# Altium schematic sheet bolid-power-dcdc-ldo.SchDoc
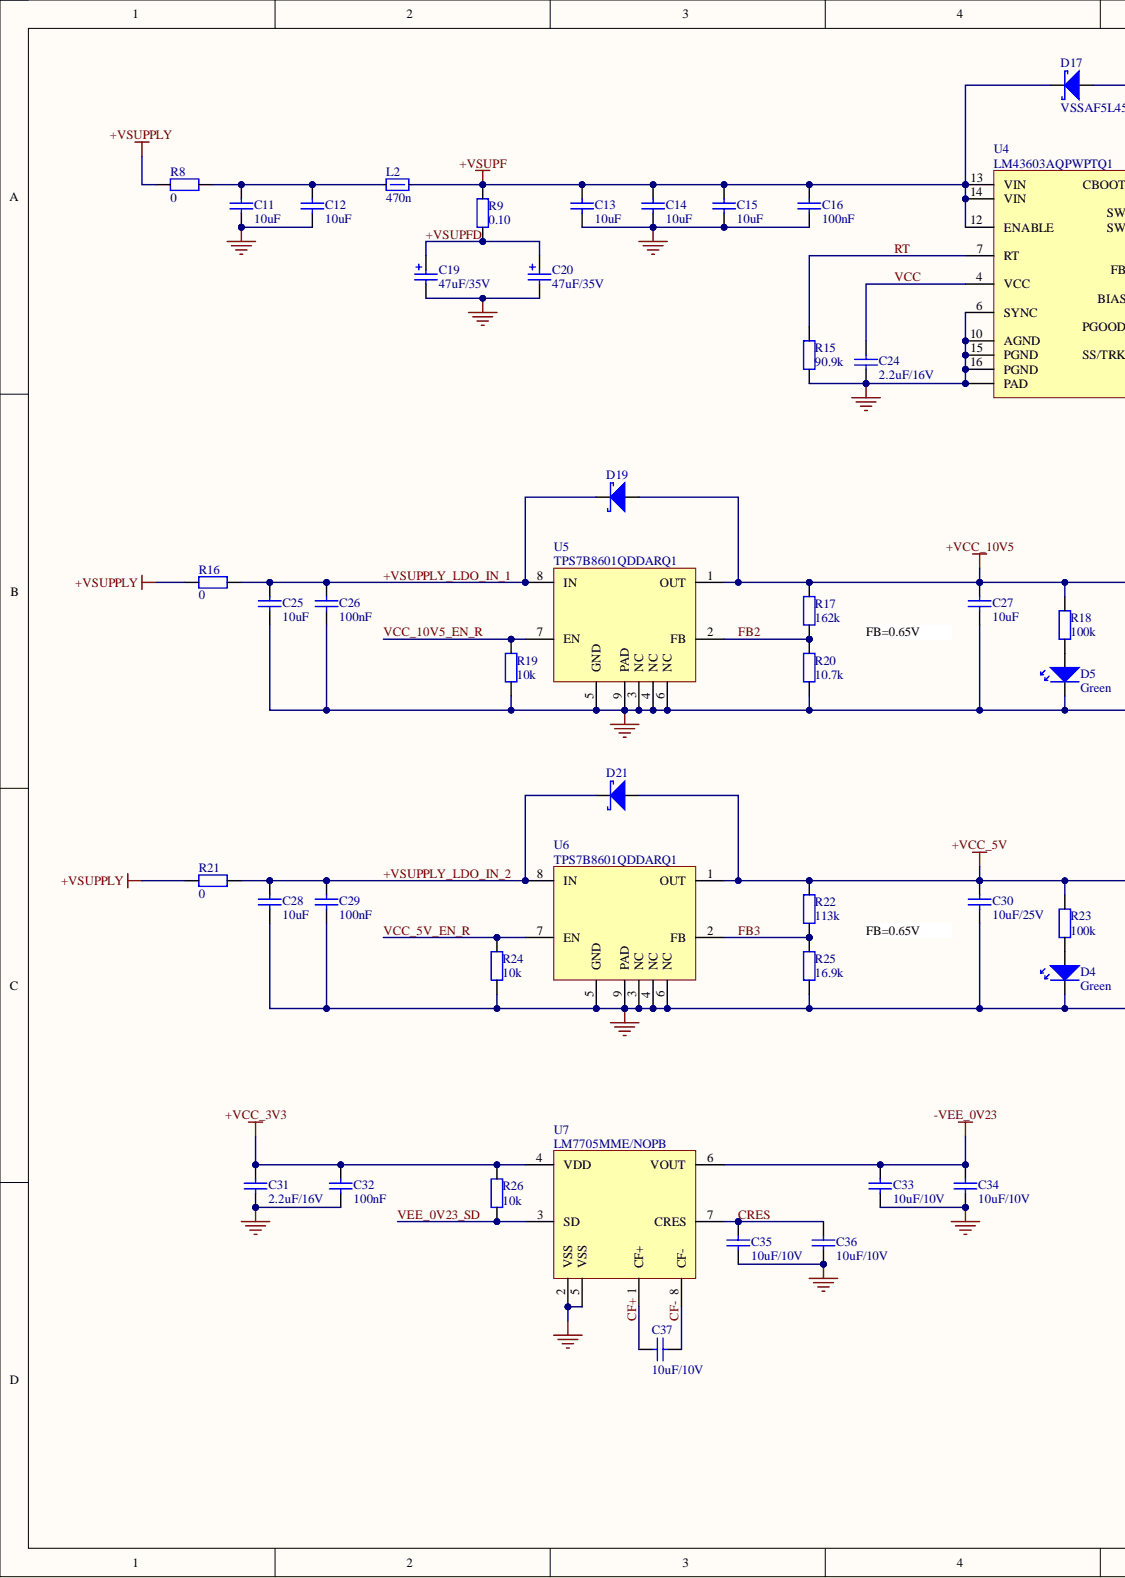
[diagram p0: bolid-power-dcdc-ldo.SchDoc, left]
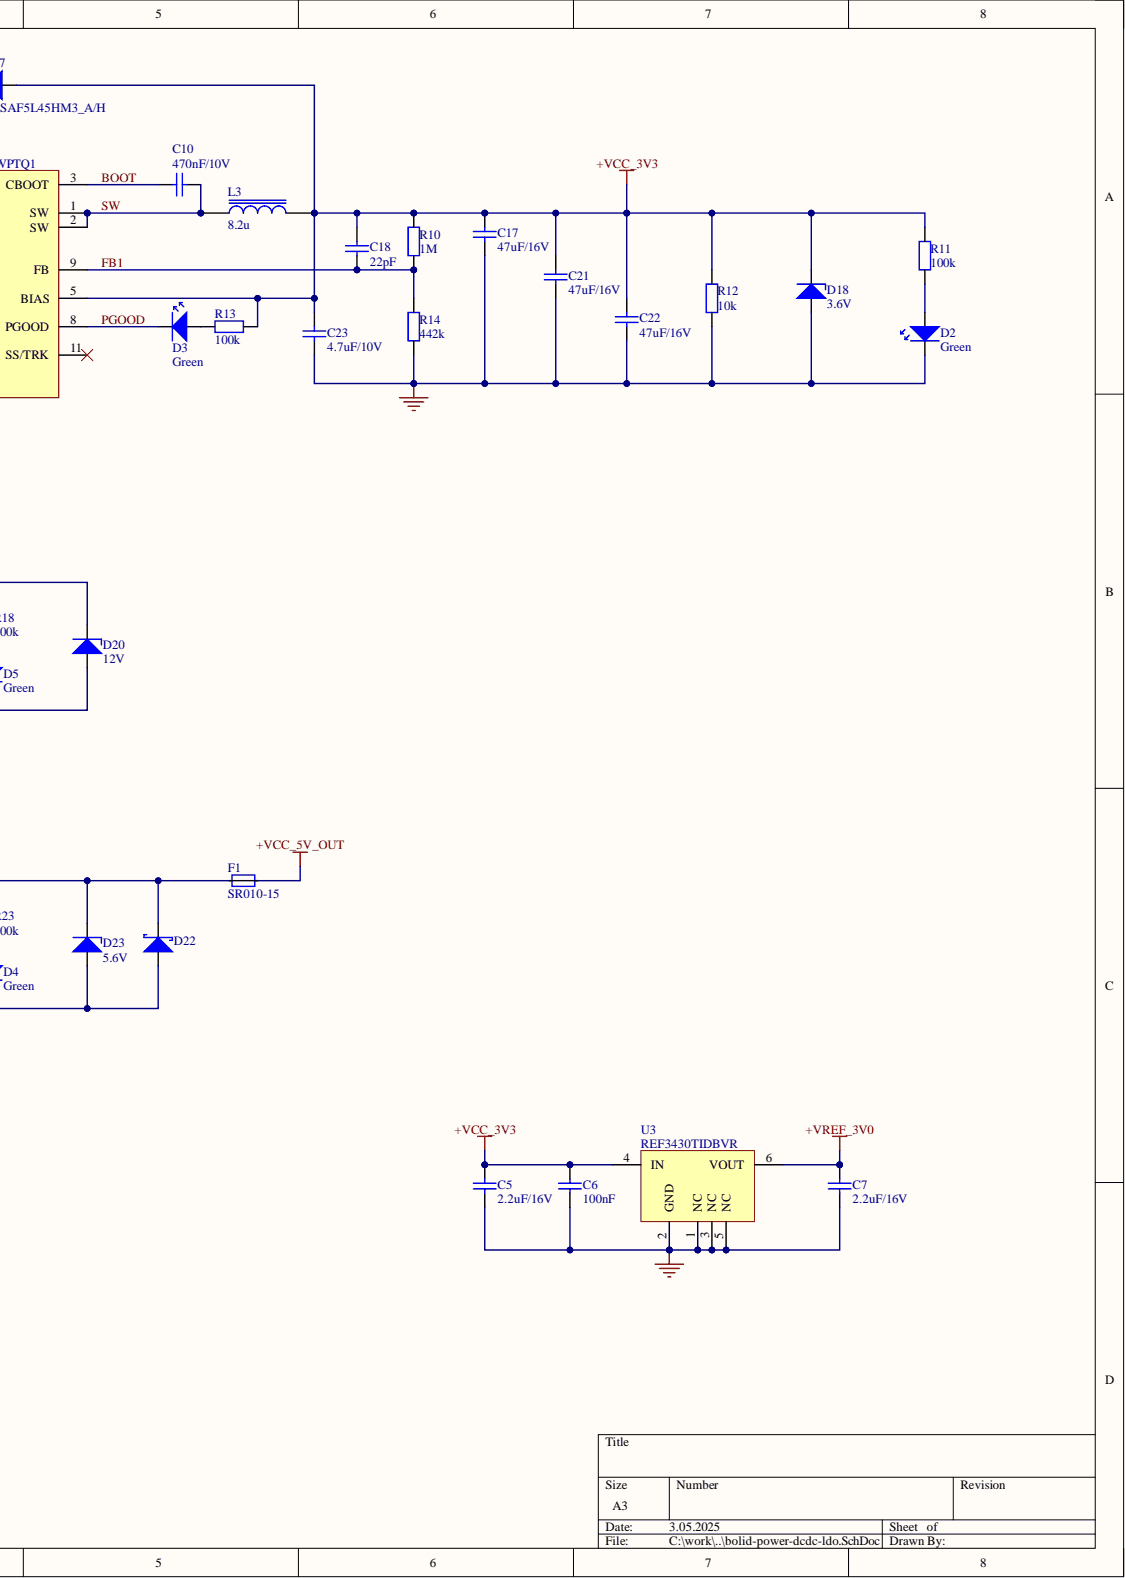
[diagram p1: bolid-power-dcdc-ldo.SchDoc, right]

## Parts
C5  Value Displayed: 2.2uF/16V  [C0603-CAPC162X80X95L35N]  (hidden comment: EMK107BB7225MA-T)
C6  value 100nF  [C0402-CAPC100X50X55L22 - Tayio Yuden TDK]  (hidden comment: GRM155R71H104KE14D)
C7  Value Displayed: 2.2uF/16V  [C0603-CAPC162X80X95L35N]  (hidden comment: EMK107BB7225MA-T)
C10  Value Displayed: 470nF/10V  [C0402-CAPC100X50X55L22 - Tayio Yuden TDK]  (hidden comment: GRM155R71A474KE01D)
C11  value 10uF  [C1210-CAPC320X250X280L55N - Kemet]  (hidden comment: C1210C106K5RACTU)
C12  value 10uF  [C1210-CAPC320X250X280L55N - Kemet]  (hidden comment: C1210C106K5RACTU)
C13  value 10uF  [C1210-CAPC320X250X280L55N - Kemet]  (hidden comment: C1210C106K5RACTU)
C14  value 10uF  [C1210-CAPC320X250X280L55N - Kemet]  (hidden comment: C1210C106K5RACTU)
C15  value 10uF  [C1210-CAPC320X250X280L55N - Kemet]  (hidden comment: C1210C106K5RACTU)
C16  value 100nF  [C0402-CAPC100X50X55L22 - Tayio Yuden TDK]  (hidden comment: GRM155R71H104KE14D)
C17  Value Displayed: 47uF/16V  [C1210-CAPC320X250X280L55N - Kemet]  (hidden comment: EMK325BJ476MM-P)
C18  Value Displayed: 22pF  [C0402-CAPC100X50X55L22 - Tayio Yuden TDK]  (hidden comment: GCM1555C1H220JA16D)
C19  Value Displayed: 47uF/35V  [C7374-43-CAPPM730X430X430L130X240N - Kemet Case X]  (hidden comment: T495X476K035ATE300)
C20  Value Displayed: 47uF/35V  [C7374-43-CAPPM730X430X430L130X240N - Kemet Case X]  (hidden comment: T495X476K035ATE300)
C21  Value Displayed: 47uF/16V  [C1210-CAPC320X250X280L55N - Kemet]  (hidden comment: EMK325BJ476MM-P)
C22  Value Displayed: 47uF/16V  [C1210-CAPC320X250X280L55N - Kemet]  (hidden comment: EMK325BJ476MM-P)
C23  Value Displayed: 4.7uF/10V  [C0402-CAPC100X50X55L22 - Tayio Yuden TDK]  (hidden comment: C1005X5R1A475M050BC)
C24  Value Displayed: 2.2uF/16V  [C0603-CAPC162X80X95L35N]  (hidden comment: EMK107BB7225MA-T)
C25  value 10uF  [C1210-CAPC320X250X280L55N - Kemet]  (hidden comment: C1210C106K5RACTU)
C26  value 100nF  [C0402-CAPC100X50X55L22 - Tayio Yuden TDK]  (hidden comment: GRM155R71H104KE14D)
C27  value 10uF  [C1210-CAPC320X250X280L55N - Kemet]  (hidden comment: C1210C106K5RACTU)
C28  value 10uF  [C1210-CAPC320X250X280L55N - Kemet]  (hidden comment: C1210C106K5RACTU)
C29  value 100nF  [C0402-CAPC100X50X55L22 - Tayio Yuden TDK]  (hidden comment: GRM155R71H104KE14D)
C30  Value Displayed: 10uF/25V  [C1206-CAPC320X160X190L65N - Murata]  (hidden comment: TMK316AB7106KL-T)
C31  Value Displayed: 2.2uF/16V  [C0603-CAPC162X80X95L35N]  (hidden comment: EMK107BB7225MA-T)
C32  value 100nF  [C0402-CAPC100X50X55L22 - Tayio Yuden TDK]  (hidden comment: GRM155R71H104KE14D)
C33  Value Displayed: 10uF/10V  [C0805-CAPC200X125X135L50N - Taiyo YudenN]  (hidden comment: GRM21BR71A106KA73K)
C34  Value Displayed: 10uF/10V  [C0805-CAPC200X125X135L50N - Taiyo YudenN]  (hidden comment: GRM21BR71A106KA73K)
C35  Value Displayed: 10uF/10V  [C0805-CAPC200X125X135L50N - Taiyo YudenN]  (hidden comment: GRM21BR71A106KA73K)
C36  Value Displayed: 10uF/10V  [C0805-CAPC200X125X135L50N - Taiyo YudenN]  (hidden comment: GRM21BR71A106KA73K)
C37  Value Displayed: 10uF/10V  [C0805-CAPC200X125X135L50N - Taiyo YudenN]  (hidden comment: GRM21BR71A106KA73K)
D2  Illumination Color: Green  [D0603 DIONC166X84X36L42N Littelfuse]  (hidden comment: 150060GS75000)
D3  Illumination Color: Green  [D0603 DIONC166X84X36L42N Littelfuse]  (hidden comment: 150060GS75000)
D4  Illumination Color: Green  [D0603 DIONC166X84X36L42N Littelfuse]  (hidden comment: 150060GS75000)
D5  Illumination Color: Green  [D0603 DIONC166X84X36L42N Littelfuse]  (hidden comment: 150060GS75000)
D17  VSSAF5L45HM3_A/H  [DO-221AC SlimSMA SODFL520X260X100L97X135N - Vishay]
D18  Zener Voltage: 3.6V  [SOT23 (TO-236AB) 04-11-04 SOT95P230X110-3N - Nexperia]  (hidden comment: BZX84-B3V6,215)
D19  MBR0530T3G  [SOD-123-2L SOD375X160X128L32X60N - Fairchild]  (value not drawn)
D20  Zener Voltage: 12V  [SOT23 (TO-236AB) 04-11-04 SOT95P230X110-3N - Nexperia]  (hidden comment: BZX84-C12,215)
D21  MBR0530T3G  [SOD-123-2L SOD375X160X128L32X60N - Fairchild]  (value not drawn)
D22  MBR0530T3G  [SOD-123-2L SOD375X160X128L32X60N - Fairchild]  (value not drawn)
D23  Zener Voltage: 5.6V  [SOT23 (TO-236AB) 04-11-04 SOT95P230X110-3N - Nexperia]  (hidden comment: BZX84-B5V6,215)
F1  SR010-15  [RESC2214X10N - SR010-15]
L2  value 470n  [MURATA DFE252012F 1008 INDC250X200X120L60N]  (hidden comment: DFE252012F-R47M=P2)
L3  value 8.2u  [Coilcraft XAL50xx]  (hidden comment: XAL5050-822MEB)
R8  value 0  [R0603-RESC160X80X55L30N]  (hidden comment: RC0603FR-070RL)
R9  value 0.10  [R0603-RESC160X80X55L30N]  (hidden comment: ERJ-3RSFR10V)
R10  value 1M  [R0402-RESC100X50X40L22N]  (hidden comment: AC0402FR-071ML)
R11  value 100k  [R0402-RESC100X50X40L22N]  (hidden comment: RC0402FR-07100KL)
R12  value 10k  [R0402-RESC100X50X40L22N]  (hidden comment: RC0402FR-0710KL)
R13  value 100k  [R0402-RESC100X50X40L22N]  (hidden comment: RC0402FR-07100KL)
R14  value 442k  [R0402-RESC100X50X40L22N]  (hidden comment: ERJ-2RKF4423X)
R15  value 90.9k  [R0402-RESC100X50X40L22N]  (hidden comment: RC0402FR-0790K9L)
R16  value 0  [R0603-RESC160X80X55L30N]  (hidden comment: RC0603FR-070RL)
R17  value 162k  [R0402-RESC100X50X40L22N]  (hidden comment: AC0402FR-07162KL)
R18  value 100k  [R0402-RESC100X50X40L22N]  (hidden comment: RC0402FR-07100KL)
R19  value 10k  [R0402-RESC100X50X40L22N]  (hidden comment: RC0402FR-0710KL)
R20  value 10.7k  [R0402-RESC100X50X40L22N]  (hidden comment: AC0402FR-0710K7L)
R21  value 0  [R0603-RESC160X80X55L30N]  (hidden comment: RC0603FR-070RL)
R22  value 113k  [R0402-RESC100X50X40L22N]  (hidden comment: RC0402FR-07113KL)
R23  value 100k  [R0402-RESC100X50X40L22N]  (hidden comment: RC0402FR-07100KL)
R24  value 10k  [R0402-RESC100X50X40L22N]  (hidden comment: RC0402FR-0710KL)
R25  value 16.9k  [R0402-RESC100X50X40L22N]  (hidden comment: RC0402FR-0716K9L)
R26  value 10k  [R0402-RESC100X50X40L22N]  (hidden comment: RC0402FR-0710KL)
U3  REF3430TIDBVR  [TI SOT23 DBV0006A SOT23-6P95_280X145L45X37N]
U4  LM43603AQPWPTQ1  [TI TSSOP16 PWP0016G SOP17P65_500X640X120L62X24T300X210N - NO PASTE REDUCTION]
U5  TPS7B8601QDDARQ1  [TI SOIC8 DDA0008E-C01 SOIC127P600X170_HS-9N]
U6  TPS7B8601QDDARQ1  [TI SOIC8 DDA0008E-C01 SOIC127P600X170_HS-9N]
U7  LM7705MME/NOPB  [TI VSSOP8 DGK0008A TSOP65P490X110-8N]

## Nets
+VCC_3V3: C5.2 C6.2 C17.2 C18.2 C21.2 C22.2 C23.2 C31.2 C32.2 D17.2 D18.3 L3.2 R10.2 R11.2 R12.2 R13.2 R26.2 U3.4(IN) U4.5(BIAS) U7.4(VDD)
+VCC_5V: C30.2 D21.2 D22.1 D23.3 F1.1 R22.2 R23.2 U6.1(OUT)
+VCC_5V_OUT: F1.2
+VCC_10V5: C27.2 D19.2 D20.3 R17.2 R18.2 U5.1(OUT)
+VREF_3V0: C7.2 U3.6(VOUT)
+VSUPF: C13.2 C14.2 C15.2 C16.2 D17.1 L2.2 R9.2 U4.12(ENABLE) U4.13(VIN) U4.14(VIN)
+VSUPPLY: R8.1 R16.1 R21.1
-VEE_0V23: C33.2 C34.2 U7.6(VOUT)
GND: C5.1 C6.1 C7.1 C11.1 C12.1 C13.1 C14.1 C15.1 C16.1 C17.1 C19.1 C20.1 C21.1 C22.1 C23.1 C24.1 C25.1 C26.1 C27.1 C28.1 C29.1 C30.1 C31.1 C32.1 C33.1 C34.1 C35.1 C36.1 D2.1 D4.1 D5.1 D18.1 D20.1 D22.2 D23.1 R12.1 R14.1 R15.1 R19.1 R20.1 R24.1 R25.1 U3.1(NC) U3.2(GND) U3.3(NC) U3.5(NC) U4.6(SYNC) U4.10(AGND) U4.15(PGND) U4.16(PGND) U4.17(PAD) U5.3(NC) U5.4(NC) U5.5(GND) U5.6(NC) U5.9(PAD) U6.3(NC) U6.4(NC) U6.5(GND) U6.6(NC) U6.9(PAD) U7.2(VSS) U7.5(VSS)
+VSUPFD: C19.2 C20.2 R9.1
+VSUPPLY_LDO_IN_1: C25.2 C26.2 D19.1 R16.2 U5.8(IN)
+VSUPPLY_LDO_IN_2: C28.2 C29.2 D21.1 R21.2 U6.8(IN)
BOOT: C10.1 U4.3(CBOOT)
CF+: C37.2 U7.1(CF+)
CF-: C37.1 U7.8(CF-)
CRES: C35.2 C36.2 U7.7(CRES)
FB1: C18.1 R10.1 R14.2 U4.9(FB)
FB2: R17.1 R20.2 U5.2(FB)
FB3: R22.1 R25.2 U6.2(FB)
PGOOD: D3.1 U4.8(PGOOD)
RT: R15.2 U4.7(RT)
SW: C10.2 L3.1 U4.1(SW) U4.2(SW)
VCC: C24.2 U4.4(VCC)
VCC_5V_EN_R: R24.2 U6.7(EN)
VCC_10V5_EN_R: R19.2 U5.7(EN)
VEE_0V23_SD: R26.1 U7.3(SD)
NetC11_2: C11.2 C12.2 L2.1 R8.2
NetD2_2: D2.2 R11.1
NetD3_2: D3.2 R13.1
NetD4_2: D4.2 R23.1
NetD5_2: D5.2 R18.1

Not connected: U4 11(SS/TRK)
